# Altium schematic sheet 10_General.SchDoc
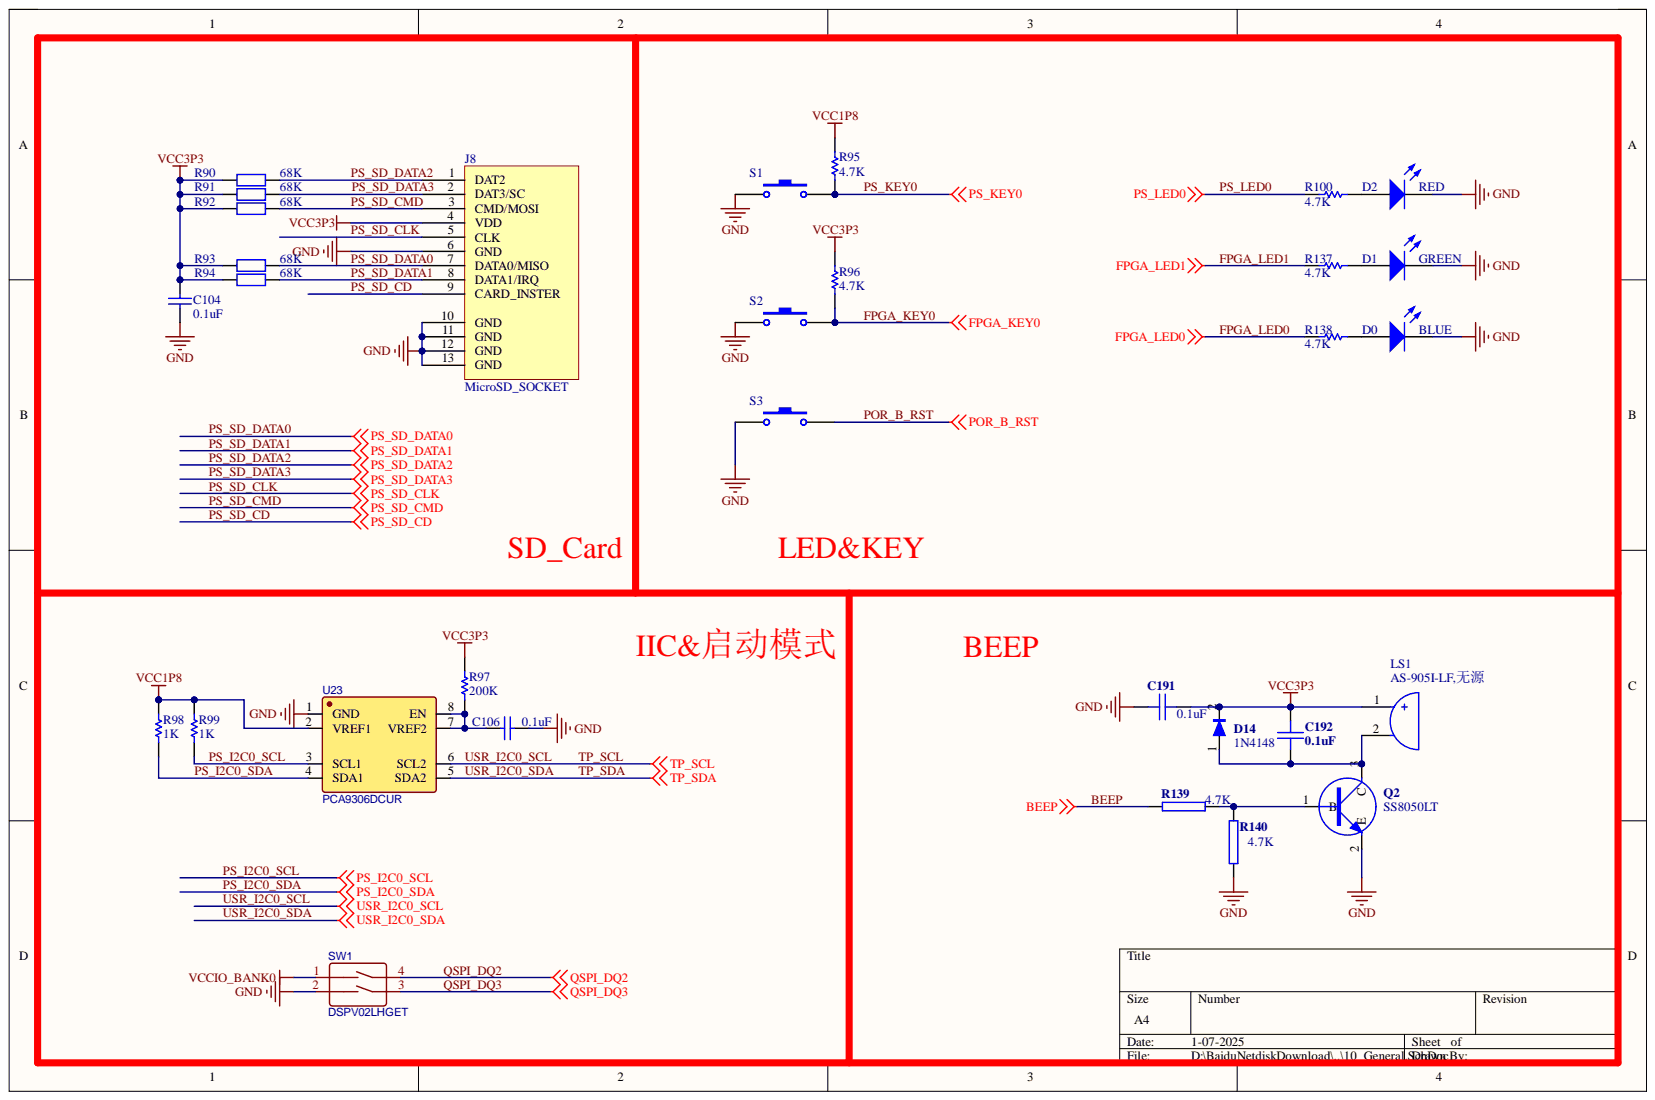
[diagram p0: 10_General.SchDoc]

## Parts
C104  0.1uF  [C0603]
C106  0.1uF  [C0603]
C191  0.1uF  [C0603]
C192  0.1uF  [C0603]
D0  BLUE  [0603_LED]
D1  GREEN  [0603_LED]
D2  RED  [0603_LED]
D14  1N4148  [SOD323]
J8  MicroSD_SOCKET  [MicroSD_SOCKET]
LS1  BUZZER2  [beep09x05]  (value not drawn)
Q2  value SS8050LT  [SOT23]  (hidden comment: NPN-1)
R90  68K  [0603R]
R91  68K  [0603R]
R92  68K  [0603R]
R93  68K  [0603R]
R94  68K  [0603R]
R95  4.7K  [0603R]
R96  4.7K  [0603R]
R97  200K  [0603R]
R98  1K  [0603R]
R99  1K  [0603R]
R100  4.7K  [0603R]
R137  4.7K  [0603R]
R138  4.7K  [0603R]
R139  4.7K  [0603R]
R140  4.7K  [0603R]
S1  SW-PB  [sw4-DIP]  (value not drawn)
S2  SW-PB  [sw4-DIP]  (value not drawn)
S3  SW-PB  [sw4-DIP]  (value not drawn)
SW1  DSPV02LHGET  [SW-TH_DSPV02LHGET]
U23  PCA9306DCUR  [us-8_l2_4-w2_1-p0_50-ls3_2-br]

## Nets
GND: C104.2 C106.1 C191.1 D0.2 D1.2 D2.2 J8.6(GND) J8.10(GND) J8.11(GND) J8.12(GND) J8.13(GND) Q2.2 R140.2 S1.1 S2.1 S3.1 SW1.2 U23.1(GND)
VCC1P8: R95.2 R98.1 R99.1 U23.2(VREF1)
VCC3P3: C104.1 C191.2 C192.2 D14.2 J8.4(VDD) LS1.1 R90.1 R91.1 R92.1 R93.1 R94.1 R96.2 R97.1
VCCIO_BANK0: SW1.1
BEEP: R139.2
FPGA_KEY0: R96.1 S2.2
FPGA_LED0: R138.1
FPGA_LED1: R137.1
POR_B_RST: S3.2
PS_I2C0_SCL: R99.2 U23.3(SCL1)
PS_I2C0_SDA: R98.2 U23.4(SDA1)
PS_KEY0: R95.1 S1.2
PS_LED0: R100.1
PS_SD_CD: J8.9(CARD_INSTER)
PS_SD_CLK: J8.5(CLK)
PS_SD_CMD: J8.3(CMD/MOSI) R92.2
PS_SD_DATA0: J8.7(DATA0/MISO) R93.2
PS_SD_DATA1: J8.8(DATA1/IRQ) R94.2
PS_SD_DATA2: J8.1(DAT2) R90.2
PS_SD_DATA3: J8.2(DAT3/SC) R91.2
QSPI_DQ2: SW1.4
QSPI_DQ3: SW1.3
TP_SCL: U23.6(SCL2)
TP_SDA: U23.5(SDA2)
NetC106_2: C106.2 R97.2 U23.7(VREF2) U23.8(EN)
NetC192_1: C192.1 D14.1 LS1.2 Q2.3
NetD0_1: D0.1 R138.2
NetD1_1: D1.1 R137.2
NetD2_1: D2.1 R100.2
NetQ2_1: Q2.1 R139.1 R140.1

## Library data of the parts
C104 C106 = Cap: Capacitor; Component Kind: Standard; LatestRevisionDate: 17-Jul-2002; LatestRevisionNote: Re-released for DXP Platform.; PackageReference: RAD-0.3; Published: 8-Jun-2000; Value: 0.1uF; Publisher: Altium Limited
  C106: Library Name: Miscellaneous Devices.IntLib; PackageDescription: Radial Cap, Thru-Hole; 2 Leads; 0.3 in Pin Spacing; Signal Integrity: Cap; Simulation: CAP
  C104: Library Name: 元件库封装.pcblib
C191 C192 = 1.02.17.0006: 0.1μF,±10%,16V,X7R,0603,RoHS; Database Table Name: VErpDataInfo; 名称: 电容; 封装: 0603; 设备封装名称: C0603_0.1uF_X7R_16V_10%; 贴片和直插: SMT; Component Kind: Standard; ComponentLink1Description: ErpDataInfo; ComponentLink1URL: http://erp.zlgmcu.com/ErpExt/ErpData/ListMateriel.aspx?pkey=1.02.17.0006; Library Name: ErpMaterialData.DbLib; RoHS: RoHS; Value: 0.1uF; 型号: 0.1μF,±10%,16V,X7R; 物料代码: 1.02.17.0006
D0 D1 = LED2: 贴片蓝色发光二极管; Component Kind: Standard; LatestRevisionDate: 17-Jul-2002; LatestRevisionNote: Re-released for DXP Platform.; Library Name: Miscellaneous Devices.IntLib; PackageDescription: SM LED; 2 Flat Leads; PackageReference: 3.2X1.6X1.1; PackageVersion: Feb-2002; Published: 8-Jun-2000; Publisher: Altium Limited
  D0: Value: BLUE
  D1: Value: GREEN
D2 = LED2: 贴片红色发光二极管; Component Kind: Standard; LatestRevisionDate: 17-Jul-2002; LatestRevisionNote: Re-released for DXP Platform.; Library Name: Miscellaneous Devices.IntLib; PackageDescription: SM LED; 2 Flat Leads; PackageReference: 3.2X1.6X1.1; PackageVersion: Feb-2002; Published: 8-Jun-2000; Value: RED; Publisher: Altium Limited
D14 = 1.04.07.0027: 1N4148,SOD-323,RoHS; Database Table Name: VErpDataInfo; 名称: 二极管; 设备封装名称: SOD-323_1N4148; 贴片和直插: SMT; Component Kind: Standard; ComponentLink1Description: ErpDataInfo; ComponentLink1URL: http://erp.zlgmcu.com/ErpExt/ErpData/ListMateriel.aspx?pkey=1.04.07.0027; Library Name: ErpMaterialData.DbLib; RoHS: RoHS; Value: 1N4148; 型号: 1N4148; 封装: SOD-323; 物料代码: 1.04.07.0027
J8 = SDCARD_TF: Component Kind: Standard; Library Name: Schlib1.SchLib
LS1 = 1.06.09.0016: AS-905I-LF,无源,Ф9×4.8mm,常州立翔,RoHS; Database Table Name: VErpDataInfo; 厂商: 常州笠翔; 名称: 讯响器; 器件优选方案: 量产物料,优先选用; 贴片和直插: THT; Component Kind: Standard; ComponentLink1Description: ErpDataInfo; ComponentLink1URL: http://erp.zlgmcu.com/ErpExt/ErpData/ListMateriel.aspx?pkey=1.06.09.0016; Library Name: ErpMaterialData.DbLib; RoHS: RoHS; Value: AS-905I-LF,无源; 型号: AS-905I-LF,无源,Ф9×4.8mm; 物料代码: 1.06.09.0016
Q2 = 1.04.09.0001: SS8050LT(1.5A),SOT-23,江苏长电,RoHS; Database Table Name: VErpDataInfo; 名称: 三极管; 设备封装名称: SOT-23_SS8050LT; 贴片和直插: SMT; Component Kind: Standard; ComponentLink1Description: ErpDataInfo; ComponentLink1URL: http://erp.zlgmcu.com/ErpExt/ErpData/ListMateriel.aspx?pkey=1.04.09.0001; Library Name: ErpMaterialData.DbLib; RoHS: RoHS; 厂商: 江苏长电; 型号: SS8050LT(1.5A); 封装: SOT-23; 物料代码: 1.04.09.0001
R90-R94 = Res2: Resistor; Component Kind: Standard; LatestRevisionDate: 17-Jul-2002; LatestRevisionNote: Re-released for DXP Platform.; PackageReference: AXIAL-0.4; Published: 8-Jun-2000; Value: 68K; Library Name: 元件封装库.pcblib; Publisher: Altium Limited
R95-R100 R137 R138 = Res1: Resistor; Component Kind: Standard; LatestRevisionDate: 17-Jul-2002; LatestRevisionNote: Re-released for DXP Platform.; Library Name: Miscellaneous Devices.IntLib; PackageDescription: Axial Device, Thru-Hole; 2 Leads; 0.3 in Pin Spacing; PackageReference: AXIAL-0.3; Published: 8-Jun-2000; Signal Integrity: Res; Simulation: RESISTOR; Publisher: Altium Limited
  R95 R96 R100 R137 R138: Value: 4.7K
  R97: Value: 200K
  R98 R99: Value: 1K
R139 R140 = 1.01.17.0019: 4.7K,±5%,0603,RALEC,RoHS; Database Table Name: VErpDataInfo; Value: 4K7; 名称: 电阻; 封装: 0603; 设备封装名称: R0603_4.7K; 贴片和直插: SMT; Component Kind: Standard; ComponentLink1Description: ErpDataInfo; ComponentLink1URL: http://erp.zlgmcu.com/ErpExt/ErpData/ListMateriel.aspx?pkey=1.01.17.0019; Library Name: ErpMaterialData.DbLib; RoHS: RoHS; 厂商: RALEC; 型号: 4.7K,±5%; 物料代码: 1.01.17.0019
S1-S3 = SW-PB: 6*6*4.5; Component Kind: Standard; LatestRevisionDate: 17-Jul-2002; LatestRevisionNote: Re-released for DXP Platform.; Library Name: zynq.SCHLIB; PackageDescription: Switch; 2 Leads; PackageReference: SPST-2; Published: 24-Mar-1999; Publisher: Altium Limited
SW1 = DSPV02LHGET: 琴键开关; BOM_Manufacturer: KE; Contributor: LCSC; BOM_JLCPCB Part Class: Extended Part; BOM_Manufacturer Part: DSPV02LHGET; BOM_Supplier: LCSC; BOM_Supplier Part: C126879; Component Kind: Standard; Library Name: zynq.SCHLIB; spicePre: S; spiceSymbolName: DSPV02LHGET
U23 = PCA9306DCUR: BOM_Manufacturer: TI; BOM_Assembly Type: smtWeld; BOM_Paste Type: expand; BOM_Manufacturer Part: PCA9306DCUR; BOM_Supplier: LCSC; BOM_Supplier Part: C53434; Component Kind: Standard; image: //image.lceda.cn/szlcsc/C53434.jpg; Library Name: Sheet3.SCHLIB; spicePre: U; spiceSymbolName: PCA9306DCUR
